# Altium schematic sheet schematic.SchDoc(schematic)
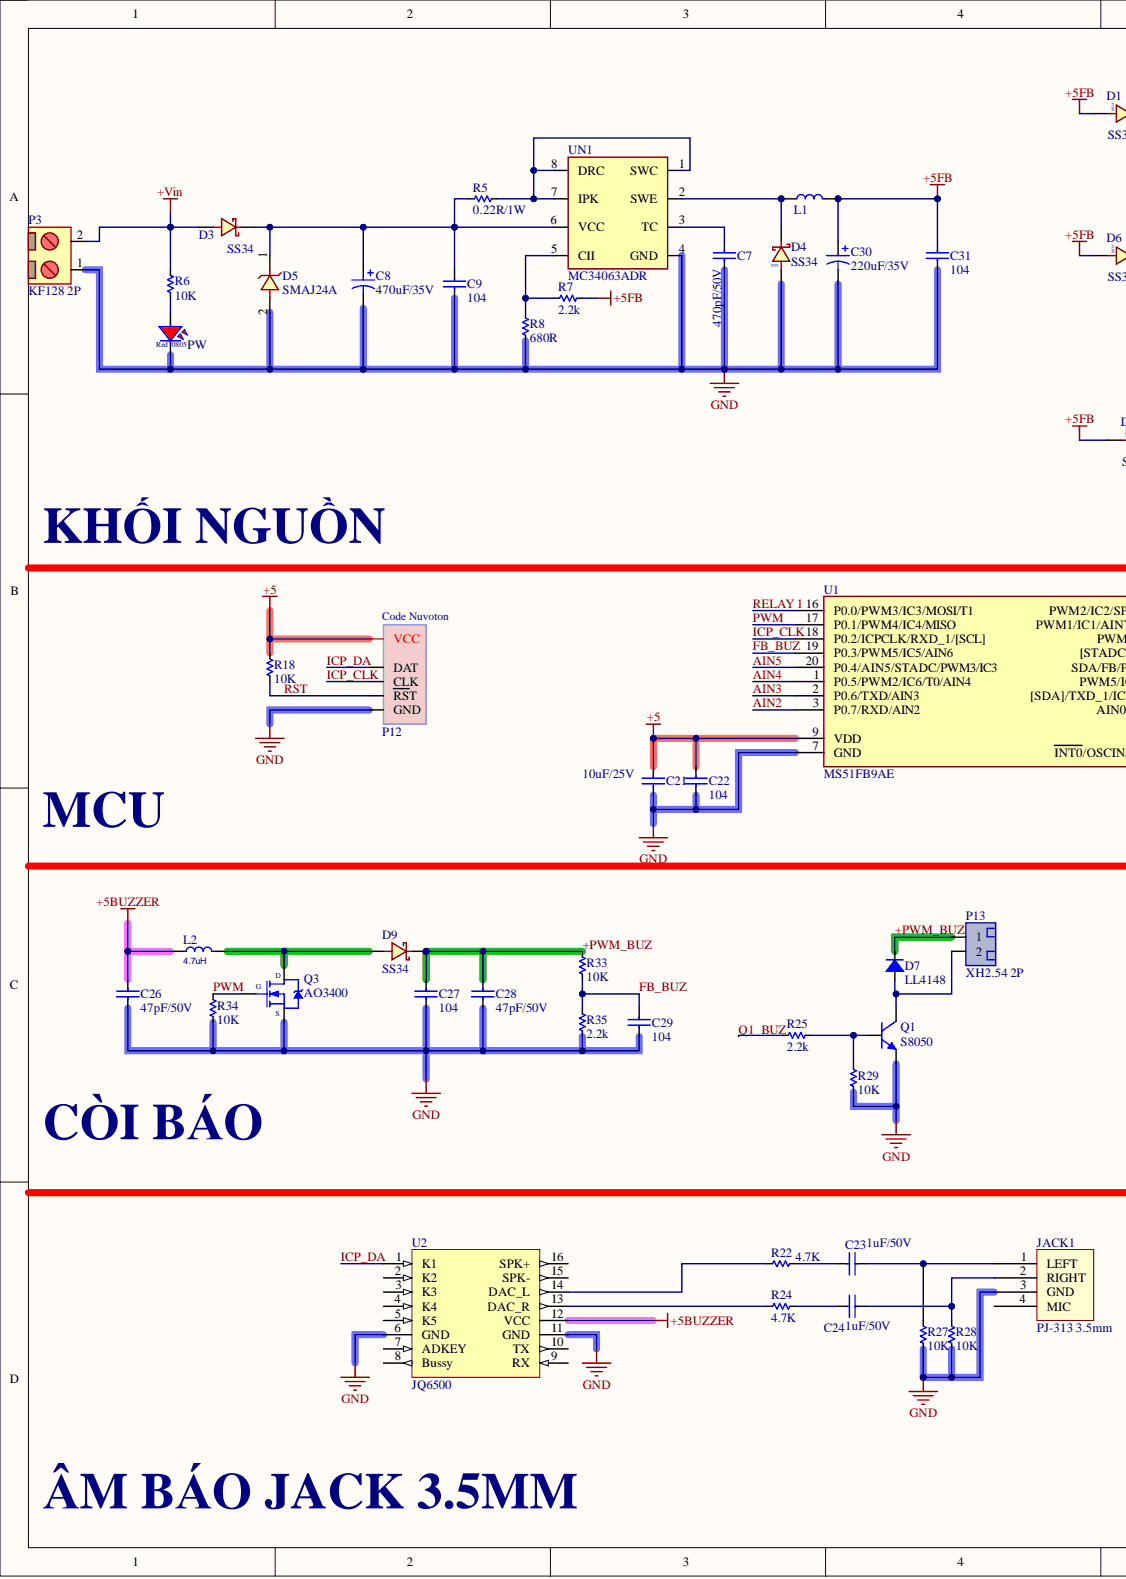
[diagram p0: schematic.SchDoc, left]
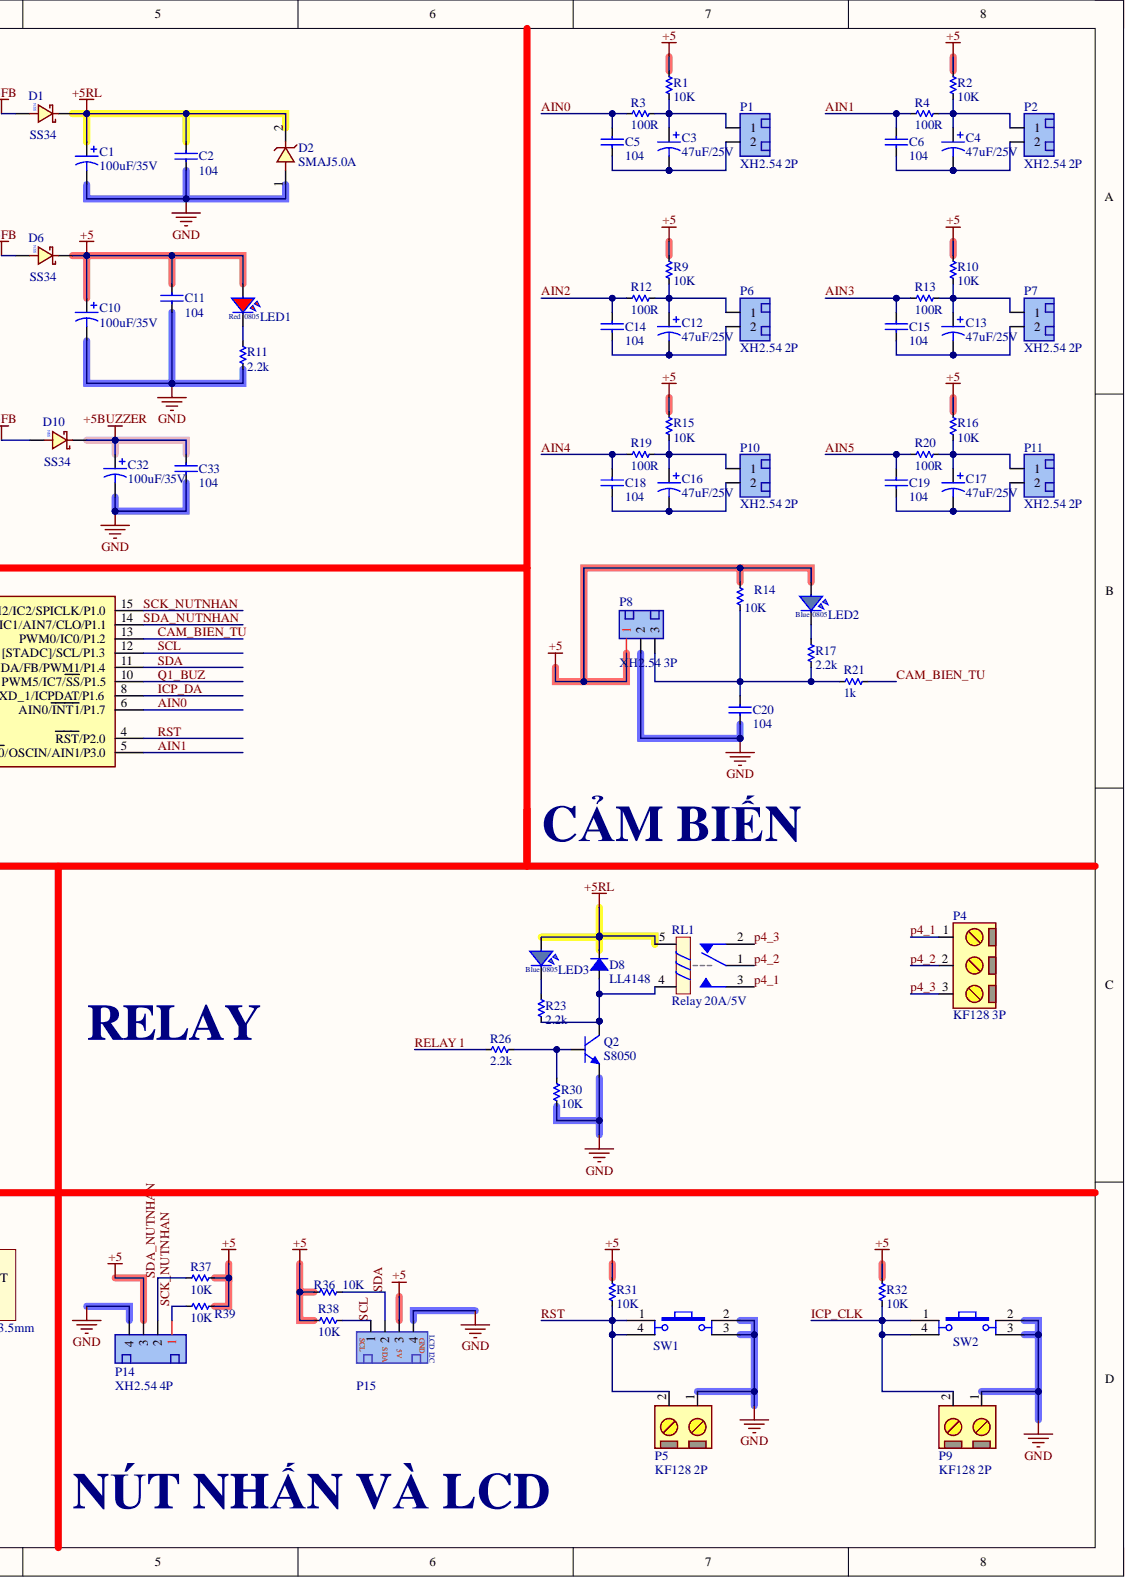
[diagram p1: schematic.SchDoc, right]

## Parts
C1  100uF/35V  [C_6.3x12]
C2  104  [C_0805_SMD]
C3  47uF/25V  [C5mm]
C4  47uF/25V  [C5mm]
C5  104  [C_0805_SMD]
C6  104  [C_0805_SMD]
C7  470pF/50V  [C_0805_SMD]
C8  470uF/35V  [C10mm]
C9  104  [C_0805_SMD]
C10  100uF/35V  [C_6.3x12]
C11  104  [C_0805_SMD]
C12  47uF/25V  [C5mm]
C13  47uF/25V  [C5mm]
C14  104  [C_0805_SMD]
C15  104  [C_0805_SMD]
C16  47uF/25V  [C5mm]
C17  47uF/25V  [C5mm]
C18  104  [C_0805_SMD]
C19  104  [C_0805_SMD]
C20  104  [C_0805_SMD]
C21  10uF/25V  [C_0805_SMD]
C22  104  [C_0805_SMD]
C23  1uF/50V  [C_0805_SMD]
C24  1uF/50V  [C_0805_SMD]
C26  47pF/50V  [C_0805_SMD]
C27  104  [C_0805_SMD]
C28  47pF/50V  [C_0805_SMD]
C29  104  [C_0805_SMD]
C30  220uF/35V  [C8X12mm]
C31  104  [C_0805_SMD]
C32  100uF/35V  [C_6.3x12]
C33  104  [C_0805_SMD]
D1  SS34  [D_SMA]
D2  SMAJ5.0A  [D_SMA]
D3  SS34  [D_SMA]
D4  SS34  [D_SMA]
D5  SMAJ24A  [DO_241AC_SMA_MFG]
D6  SS34  [D_SMA]
D7  LL4148  [SOD80C_MFG]
D8  LL4148  [SOD80C_MFG]
D9  SS34  [D_SMA]
D10  SS34  [D_SMA]
JACK1  PJ-313 3.5mm  [PJ-320A-PCB]
L1  220uH  [CDRH74]  (value not drawn)
L2  4.7uH  [CD53]
LED1  LED RED  [LED 0805 Red]  (value not drawn)
LED2  LED BLUE  [LED 0805 Blue]  (value not drawn)
LED3  LED BLUE  [LED 0805 Blue]  (value not drawn)
P1  XH2.54 2P  [XH2.54_2P]
P2  XH2.54 2P  [XH2.54_2P]
P3  KF128 2P  [KF128 5.08 2P]
P4  KF128 3P  [KF128 5.08 3P]
P5  KF128 2P  [KF128 5.08 2P]
P6  XH2.54 2P  [XH2.54_2P]
P7  XH2.54 2P  [XH2.54_2P]
P8  XH2.54 3P  [XH2.54_3P]
P9  KF128 2P  [KF128 5.08 2P]
P10  XH2.54 2P  [XH2.54_2P]
P11  XH2.54 2P  [XH2.54_2P]
P12  Nuvoton  [Header Code Nuvoton 2.54 6P]  (no BOM)
P13  XH2.54 2P  [XH2.54_2P]
P14  XH2.54 4P  [XH2.54_4P]
P15  XH2.54 4P  [XH2.54_4P]  (value not drawn)
PW  LED RED  [LED 0805 Red]  (value not drawn)
Q1  S8050  [SOT23_N NXP]
Q2  S8050  [SOT23_N NXP]
Q3  AO3400  [SOT23_N NXP]
R1  10K  [RES-SMD-0805]
R2  10K  [RES-SMD-0805]
R3  100R  [RES-SMD-0805]
R4  100R  [RES-SMD-0805]
R5  0.22R/1W  [RES-SMD-2512]
R6  10K  [RES-SMD-0805]
R7  2.2k  [RES-SMD-0805]
R8  680R  [RES-SMD-0805]
R9  10K  [RES-SMD-0805]
R10  10K  [RES-SMD-0805]
R11  2.2k  [RES-SMD-0805]
R12  100R  [RES-SMD-0805]
R13  100R  [RES-SMD-0805]
R14  10K  [RES-SMD-0805]
R15  10K  [RES-SMD-0805]
R16  10K  [RES-SMD-0805]
R17  2.2k  [RES-SMD-0805]
R18  10K  [RES-SMD-0805]
R19  100R  [RES-SMD-0805]
R20  100R  [RES-SMD-0805]
R21  1k  [RES-SMD-0805]
R22  4.7K  [RES-SMD-0805]
R23  2.2k  [RES-SMD-0805]
R24  4.7K  [RES-SMD-0805]
R25  2.2k  [RES-SMD-0805]
R26  2.2k  [RES-SMD-0805]
R27  10K  [RES-SMD-0805]
R28  10K  [RES-SMD-0805]
R29  10K  [RES-SMD-0805]
R30  10K  [RES-SMD-0805]
R31  10K  [RES-SMD-0805]
R32  10K  [RES-SMD-0805]
R33  10K  [RES-SMD-0805]
R34  10K  [RES-SMD-0805]
R35  2.2k  [RES-SMD-0805]
R36  10K  [RES-SMD-0805]
R37  10K  [RES-SMD-0805]
R38  10K  [RES-SMD-0805]
R39  10K  [RES-SMD-0805]
RL1  Relay 20A/5V  [Relay 5 pin SRA AL]
SW1  BT4  [SW4]  (value not drawn)
SW2  BT4  [SW4]  (value not drawn)
U1  MS51FB9AE  [SOG65_20]
U2  JQ6500  [JQ6500 md]
UN1  MC34063ADR  [SO_8]

## Nets
+5: C10.1 C11.1 C21.1 C22.1 D6.2 LED1.1 LED2.1 P8.1 P12.1(VCC) P14.3 P15.3 R1.1 R2.1 R9.1 R10.1 R14.1 R15.1 R16.1 R18.1 R31.1 R32.1 R36.2 R37.2 R38.2 R39.2 U1.9(VDD)
+5BUZZER: C26.2 C32.1 C33.1 D10.2 L2.1 U2.12(VCC)
+5FB: C30.1 C31.1 D1.1 D6.1 D10.1 L1.2 R7.1
+5RL: C1.1 C2.1 D1.2 D2.2 D8.1 LED3.1 RL1.5
+Vin: D3.1 P3.2 R6.1
GND: C1.2 C2.2 C7.1 C8.2 C9.1 C10.2 C11.2 C20.2 C21.2 C22.2 C26.1 C27.1 C28.1 C29.1 C30.2 C31.2 C32.2 C33.2 D2.1 D4.1 D5.2 JACK1.3(GND) P3.1 P5.1 P8.2 P9.1 P12.6(GND) P14.4 P15.4 PW.2 Q1.2 Q2.2 Q3.2 R8.2 R11.2 R27.2 R28.2 R29.1 R30.1 R34.2 R35.2 SW1.2 SW1.3 SW2.2 SW2.3 U1.7(GND) U2.6(GND) U2.11(GND) UN1.4(GND)
+PWM_BUZ: C27.2 C28.2 D7.1 D9.2 P13.1 R33.1
AIN0: C5.1 R3.2 U1.6(AIN0/INT1/P1.7)
AIN1: C6.1 R4.2 U1.5(INT0/OSCIN/AIN1/P3.0)
AIN2: C14.1 R12.2 U1.3(P0.7/RXD/AIN2)
AIN3: C15.1 R13.2 U1.2(P0.6/TXD/AIN3)
AIN4: C18.1 R19.2 U1.1(P0.5/PWM2/IC6/T0/AIN4)
AIN5: C19.1 R20.2 U1.20(P0.4/AIN5/STADC/PWM3/IC3)
CAM_BIEN_TU: R21.1 U1.13(PWM0/IC0/P1.2)
FB_BUZ: C29.2 R33.2 R35.1 U1.19(P0.3/PWM5/IC5/AIN6)
ICP_CLK: P9.2 P12.4(CLK) R32.2 SW2.1 SW2.4 U1.18(P0.2/ICPCLK/RXD_1/[SCL])
ICP_DA: P12.3(DAT) U1.8([SDA]/TXD_1/ICPDAT/P1.6) U2.1(K1)
p4_1: P4.1 RL1.3
p4_2: P4.2 RL1.1
p4_3: P4.3 RL1.2
PWM: Q3.1 R34.1 U1.17(P0.1/PWM4/IC4/MISO)
Q1_BUZ: R25.2 U1.10(PWM5/IC7/SS/P1.5)
RELAY 1: R26.2 U1.16(P0.0/PWM3/IC3/MOSI/T1)
RST: P5.2 P12.5(RST) R18.2 R31.2 SW1.1 SW1.4 U1.4(RST/P2.0)
SCK_NUTNHAN: P14.1 R39.1 U1.15(PWM2/IC2/SPICLK/P1.0)
SCL: P15.1 R38.1 U1.12([STADC]/SCL/P1.3)
SDA: P15.2 R36.1 U1.11(SDA/FB/PWM1/P1.4)
SDA_NUTNHAN: P14.2 R37.1 U1.14(PWM1/IC1/AIN7/CLO/P1.1)
NetC3_1: C3.1 P1.1 R1.2 R3.1
NetC3_2: C3.2 C5.2 P1.2
NetC4_1: C4.1 P2.1 R2.2 R4.1
NetC4_2: C4.2 C6.2 P2.2
NetC7_2: C7.2 UN1.3(TC)
NetC8_1: C8.1 C9.2 D3.2 D5.1 R5.2 UN1.6(VCC)
NetC12_1: C12.1 P6.1 R9.2 R12.1
NetC12_2: C12.2 C14.2 P6.2
NetC13_1: C13.1 P7.1 R10.2 R13.1
NetC13_2: C13.2 C15.2 P7.2
NetC16_1: C16.1 P10.1 R15.2 R19.1
NetC16_2: C16.2 C18.2 P10.2
NetC17_1: C17.1 P11.1 R16.2 R20.1
NetC17_2: C17.2 C19.2 P11.2
NetC20_1: C20.1 P8.3 R14.2 R17.2 R21.2
NetC23_1: C23.1 JACK1.1(LEFT) R27.1
NetC23_2: C23.2 R22.1
NetC24_1: C24.1 JACK1.2(RIGHT) R28.1
NetC24_2: C24.2 R24.1
NetD4_2: D4.2 L1.1 UN1.2(SWE)
NetD7_2: D7.2 P13.2 Q1.3
NetD8_2: D8.2 Q2.3 R23.2 RL1.4
NetD9_1: D9.1 L2.2 Q3.3
NetLED1_2: LED1.2 R11.1
NetLED2_2: LED2.2 R17.1
NetLED3_2: LED3.2 R23.1
NetPW_1: PW.1 R6.2
NetQ1_1: Q1.1 R25.1 R29.2
NetQ2_1: Q2.1 R26.1 R30.2
NetR5_1: R5.1 UN1.1(SWC) UN1.7(IPK) UN1.8(DRC)
NetR7_2: R7.2 R8.1 UN1.5(CII)
NetR22_2: R22.2 U2.14(DAC_L)
NetR24_2: R24.2 U2.13(DAC_R)

Not connected: JACK1 4(MIC); U2 2(K2) 3(K3) 4(K4) 5(K5) 7(ADKEY) 8(Bussy) 9(RX) 10(TX) 15(SPK-) 16(SPK+)

## Library data of the parts
C1 C10 C32 = Cap Pol 100uF/35V: Polarized Capacitor (Axial) 100uF 35V; Code: 1402-225; Component Kind: Standard; Library Name: RD Integrated_Library.IntLib
C2 C5 C6 C9 C11 C14 C15 C18-C20 C22 C27 C29 C31 C33 = Cap 0805 104 100nF: Cap SMD 0805 104-100nF-0.1uF 50V; Code: 1438-080; Component Kind: Standard; Library Name: RD Integrated_Library.IntLib
C3 C4 C12 C13 C16 C17 = Cap Pol 47uF/25V: Polarized Capacitor (Axial) 47uF 25V; Code: 1402-103; Component Kind: Standard; Library Name: RD Integrated_Library.IntLib
C7 = Cap 0805 470pF 50V: Cap SMD 0805  470pF 50V; Code: 1438-056; Component Kind: Standard; Library Name: RD Integrated_Library.IntLib
C8 = Cap Pol 470uF/35V: Polarized Capacitor (Axial) 470uF 35V; Code: 1402-231; Component Kind: Standard; Library Name: RD Integrated_Library.IntLib
C21 = Cap 0805 10uF 25V: Cap SMD 0805 10uF; Code: 1436-100; Component Kind: Standard; Library Name: RD Integrated_Library.IntLib
C23 C24 = Cap 0805 1uF 50V: Cap SMD 0805 105 - 1uF 50V; Code: 1438-092; Component Kind: Standard; Library Name: RD Integrated_Library.IntLib
C26 C28 = Cap 0805 47pF 50V: Capacitor SMD 0805; Code: 1438-034; Designed by: TranLuyen; Component Kind: Standard; Library Name: RD Integrated_Library.IntLib
C30 = Cap Pol 220uF/35V: Polarized Capacitor (Axial) 220uF 35V; Code: 1402-228; Component Kind: Standard; Library Name: RD Integrated_Library.IntLib
D1 D3 D4 D6 D9 D10 = SS34-SMA: Surface-Mount Schottky Barrier Rectifier; Code: 2005-008; Component Kind: Standard; Library Name: RD Integrated_Library.IntLib
  D1 D4 D6 D10: Value: SS34
D2 = TVS SMAJ5_0A-E3-61-DO241AC: SMAJ5.0A Series 400 W 5 V Surface Mount Uni-Directional TVS Diode - DO-214AC; Code: 2006-010; Designed by: TranLuyen; Component Kind: Standard; ComponentLink1Description: Datasheet; ComponentLink1URL: https://datasheet.octopart.com/SMAJ5.0AHE3-61-Vishay-datasheet-15599035.pdf; Library Name: RD Integrated_Library.IntLib
D5 = TVS SMAJ24A-DO241AC: SMAJ Series 400W Surface Mount Uni-Directional TVS Diode - DO-214AC; Designed by: TranLuyen; Code: N/A; Component Kind: Standard; Library Name: RD Integrated_Library.IntLib
D7 D8 = LL4148: 0.2A, 100V, SOD80; Code: 2001-012; Designed by: Nhox; Component Kind: Standard; Library Name: RD Integrated_Library.IntLib
L1 = CD74 7345-221 220uH: INDUCTOR SMD; Code: 1526-001; Component Kind: Standard; Library Name: RD Integrated_Library.IntLib
L2 = CD53 5852-220 22uH 1.5A: INDUCTOR, POWER, SMD; Code: 1523-012; Component Kind: Standard; Library Name: RD Integrated_Library.IntLib
LED1 PW = LED 0805 RED: LED 0805 SMD RED; Code: 8600-017; Designed by: TranLuyen; Component Kind: Standard; Library Name: RD Integrated_Library.IntLib
LED2 LED3 = LED 0805 BLUE: LED 0805 SMD BLUE; Code: 8600-019; Designed by: TranLuyen; Component Kind: Standard; Library Name: RD Integrated_Library.IntLib
P1 P2 P6 P7 P10 P11 P13 = XH2.54 HEADER 2 PIN: Header XH2.54mm P; Code: 3509-001; ComponentLink1 Description: Manufacturer; ComponentLink2 Description: Datasheet; Component Kind: Standard; ComponentLink1URL: http://www.we-online.com; ComponentLink2URL: http://katalog.we-online.de/em/datasheet/6130xx143121.pdf; Library Name: RD Integrated_Library.IntLib
P3 P5 P9 = KF128-5.08-2P-5.08mm: Header, 2-Pin, Medium KF128 2P-5.08mm; Code: 3520-013; ComponentLink1 Description: Manufacturer; ComponentLink2 Description: Datasheet; Designed by: TranLuyen; Component Kind: Standard; ComponentLink1URL: http://www.we-online.com; ComponentLink2URL: http://katalog.we-online.de/em/datasheet/6911031100xx.pdf; Library Name: RD Integrated_Library.IntLib
P4 = KF128-5.08-3P-5.08mm: Header, 3-Pin, Medium KF128 3P-5.08mm; Code: 3520-014; Designed by: TranLuyen; Component Kind: Standard; Library Name: RD Integrated_Library.IntLib
P8 = XH2.54 HEADER 3 Pin: XH-T-3 HEADER 3 Pin XH2.54; Code: 3509-002; Component Kind: Standard; Library Name: RD Integrated_Library.IntLib
P12 = Header Code Nuvoton: Header 6-5Pin; Library Name: RD Integrated_Library.IntLib
P14 = XH2.54 HEADER 4 Pin: XH-T-4 HEADER 4 Pin XH2.54; Code: 3509-003; Component Kind: Standard; Library Name: RD Integrated_Library.IntLib
P15 = Header LCD I2C: XH-T-4 HEADER 4 Pin XH2.54; Code: 3509-003; Component Kind: Standard; Library Name: RD Integrated_Library.IntLib
Q1 Q2 = S8050 SOT-23: J3Y-NPN SMD Transistor SOT23; Code: 1701-070; Component Kind: Standard; Library Name: RD Integrated_Library.IntLib
Q3 = AO3400: N-Channel 1.4W, Vds = 30V, Vgs = +-12V, Id = 4.9A; Code: 1901-0360; Designed by: Nhox; Component Kind: Standard; Library Name: RD Integrated_Library.IntLib
R1 R2 R6 R9 R10 R14-R16 R18 R27-R34 R36-R39 = Res 10k 0805: Resistor SMD 0805; Code: 1024-111; Component Kind: Standard; Library Name: RD Integrated_Library.IntLib
R3 R4 R12 R13 R19 R20 = Res 100R 0805: Resistor SMD 0805; Code: 1025-063; Component Kind: Standard; Library Name: RD Integrated_Library.IntLib
R5 = Res 0.22R 2512 1W: Resistor SMD 2512 1W; Code: 1032-005; Component Kind: Standard; Library Name: RD Integrated_Library.IntLib
R7 R11 R17 R23 R25 R26 R35 = Res 2.2K 0805: Resistor SMD 0805; Code: 1024-094; Component Kind: Standard; Library Name: RD Integrated_Library.IntLib
R8 = Res 680R 0805: Resistor SMD 0805; Code: 1024-082; Component Kind: Standard; Library Name: RD Integrated_Library.IntLib; Value: 680R
R21 = Res 1k 0805: Resistor SMD 0805; Code: 1024-086; Component Kind: Standard; Library Name: RD Integrated_Library.IntLib
R22 R24 = Res 4.7k 0805: Resistor SMD 0805; Code: 1024-103; Component Kind: Standard; Library Name: RD Integrated_Library.IntLib
RL1 = Relay 5V 5 Pin 20A SRA: Relay SPDT,20A - 5VDC 5 Pin SONGLE SRA; Code: 2401-001; Component Kind: Standard; Library Name: RD Integrated_Library.IntLib
SW1 SW2 = SW4 DIP 6x6x5mm: Switch button 4 pin 6x6x5mm DIP; Code: 3610-003; Component Kind: Standard; Library Name: RD Integrated_Library.IntLib
U1 = MS51FB9AE: MS51FB9AE, ROM 16KB, RAM 256B + 1KB, SPROM 128B, 1T 8051-based CMOS up to 24Mhz; Code: 7201-019; Designed by: Nhox; Component Kind: Standard; Library Name: RD Integrated_Library.IntLib
U2 = Module JQ6500: Code: 7006-050; Component Kind: Standard; Library Name: RD Integrated_Library.IntLib
UN1 = MC34063ADR: MC3x063A 1.5-A Peak Boost/Buck/Inverting Switching Regulators; Code: 5015-078; Designed by: TranLuyen; Component Kind: Standard; ComponentLink1Description: Datasheet; ComponentLink1URL: http://www.ti.com/lit/ds/symlink/mc33063a.pdf?src-supplier=Digi-Key; Library Name: RD Integrated_Library.IntLib
JACK1 = PJ-313 3.5mm: Component Kind: Standard; Library Name: PJ-320A.SchLib
